# Altium schematic sheet Page1_Microcontrolador.SchDoc
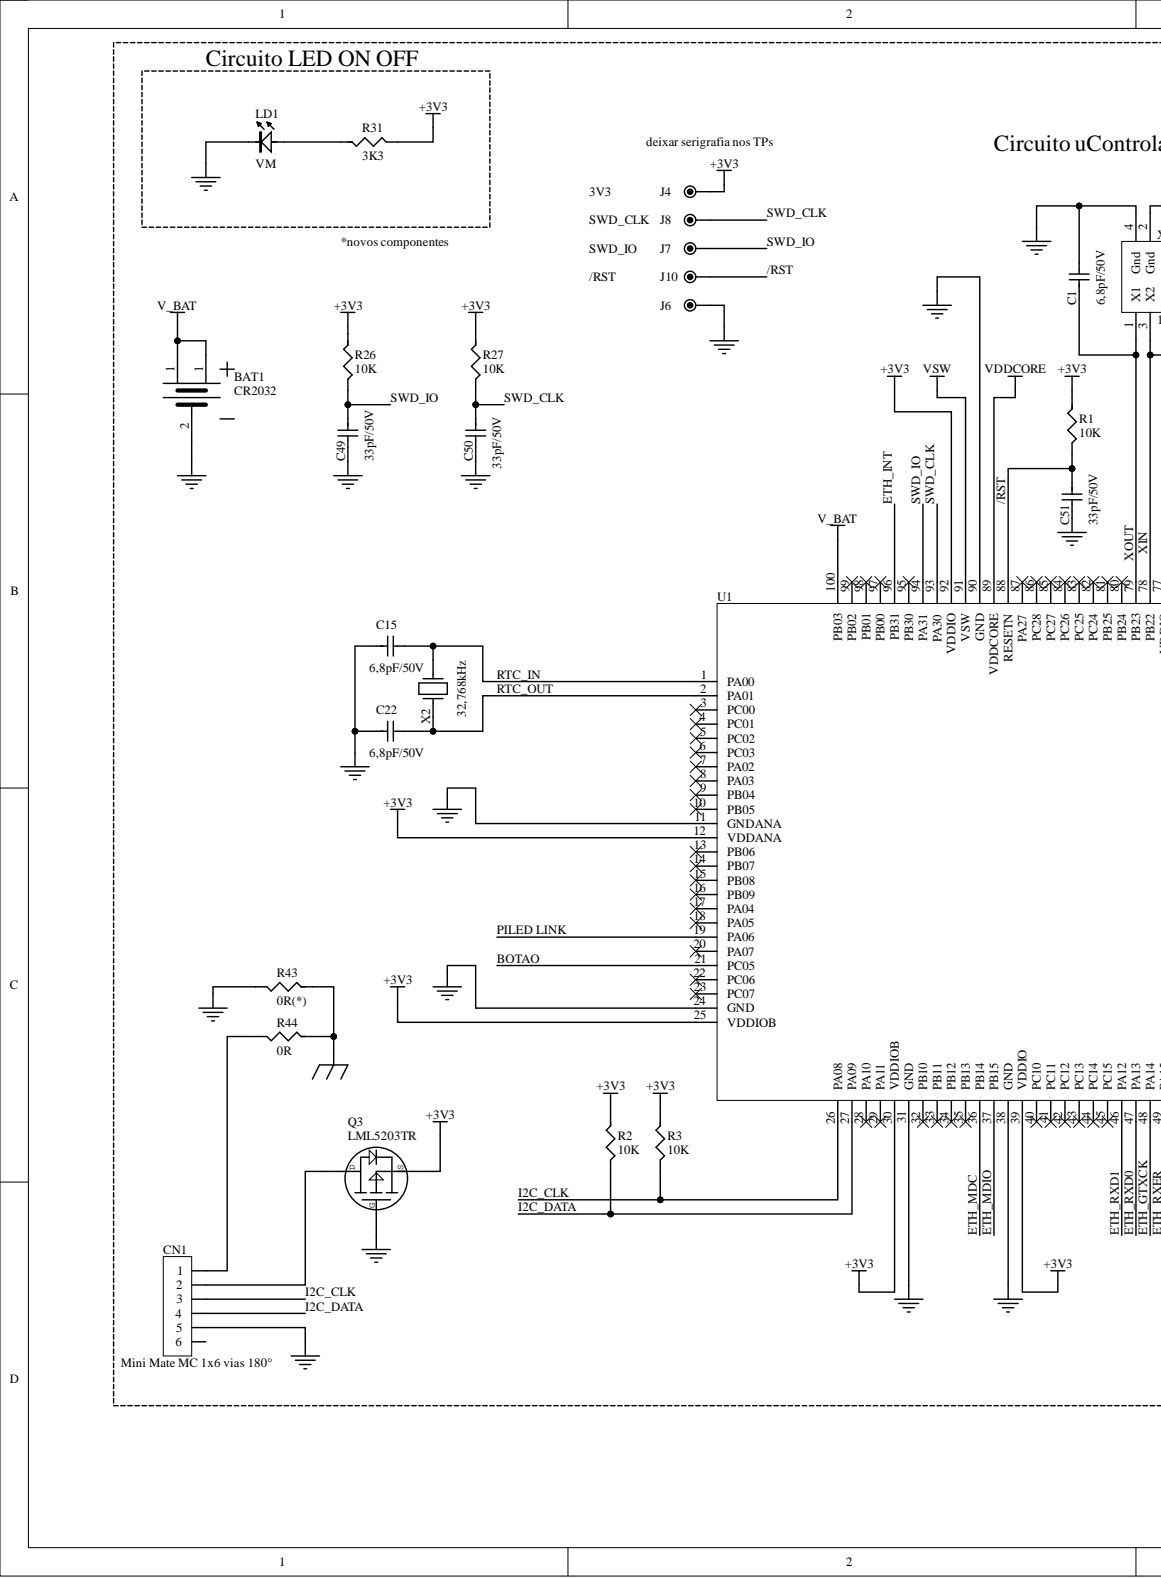
[diagram p0: Page1_Microcontrolador.SchDoc, left]
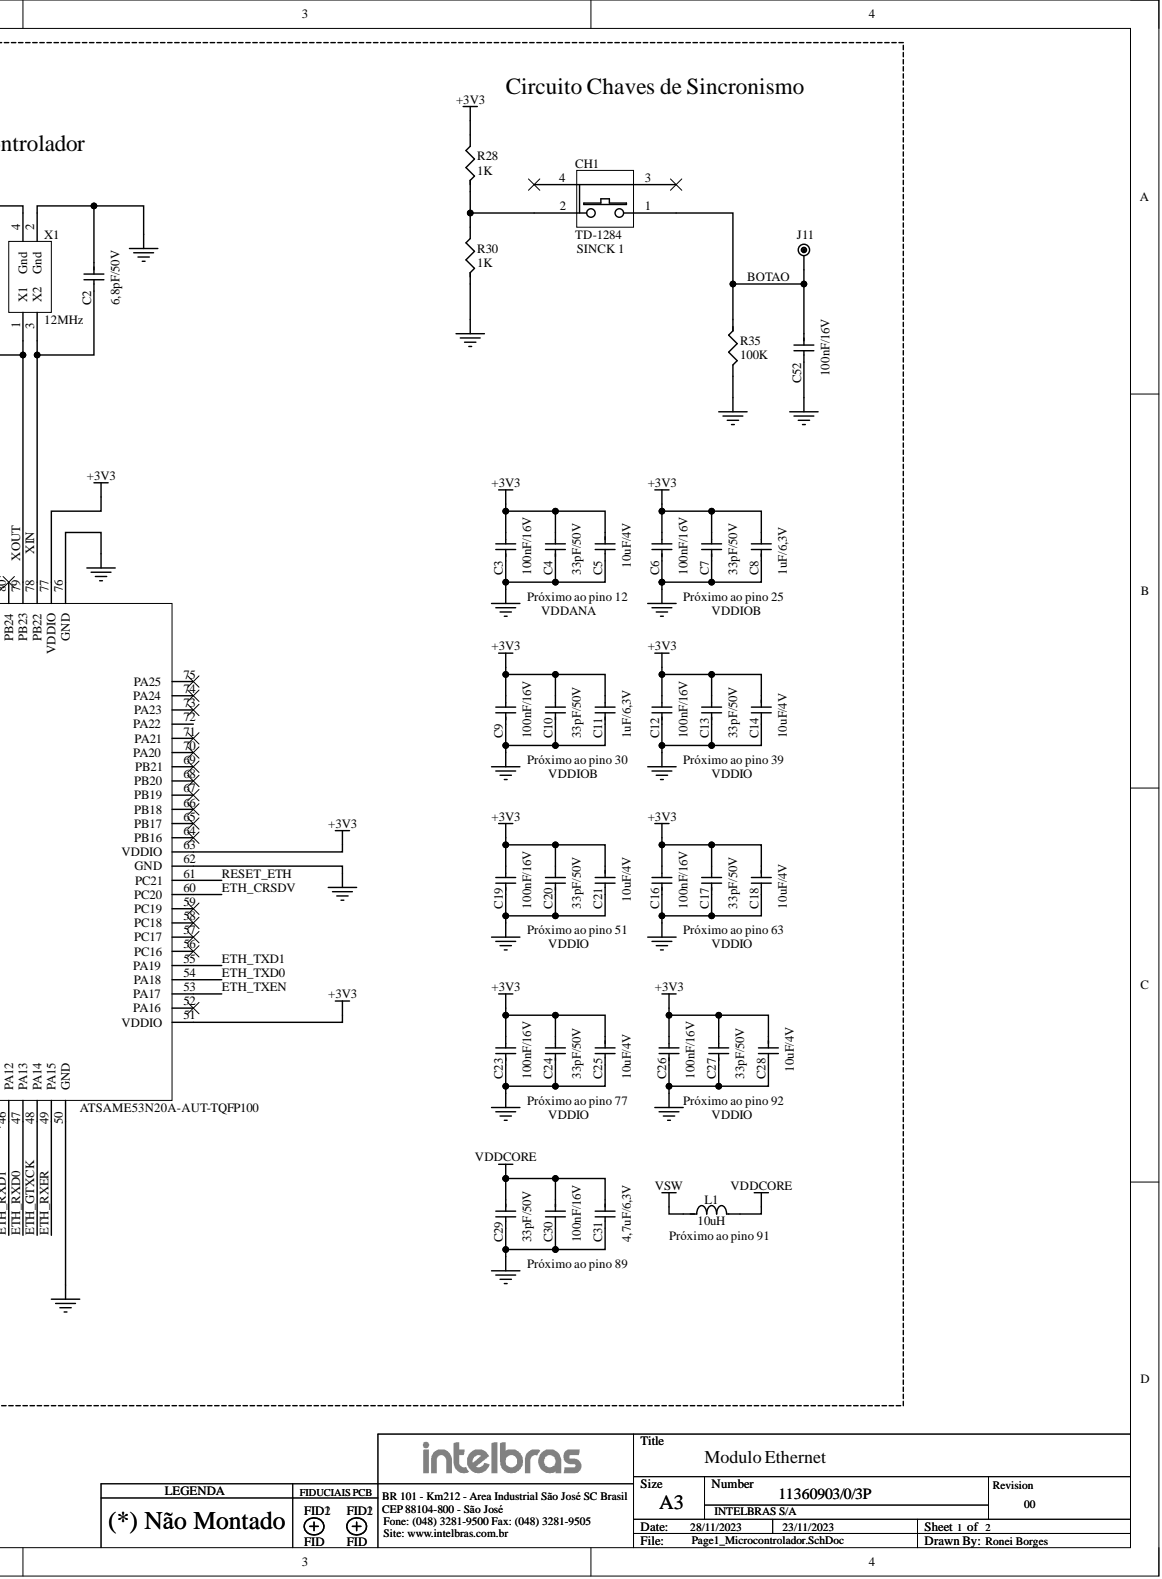
[diagram p1: Page1_Microcontrolador.SchDoc, right]

## Parts
BAT1  CR2032  [BAT_SMD CR2032 - 123]
C1  6,8pF/50V  [0402C]
C2  6,8pF/50V  [0402C]
C3  100nF/16V  [0402C]
C4  33pF/50V  [0402C]
C5  10uF/4V  [0603]
C6  100nF/16V  [0402C]
C7  33pF/50V  [0402C]
C8  1uF/6,3V  [0402C]
C9  100nF/16V  [0402C]
C10  33pF/50V  [0402C]
C11  1uF/6,3V  [0402C]
C12  100nF/16V  [0402C]
C13  33pF/50V  [0402C]
C14  10uF/4V  [0603C]
C15  6,8pF/50V  [0402C]
C16  100nF/16V  [0402C]
C17  33pF/50V  [0402C]
C18  10uF/4V  [0603C]
C19  100nF/16V  [0402C]
C20  33pF/50V  [0402C]
C21  10uF/4V  [0603C]
C22  6,8pF/50V  [0402C]
C23  100nF/16V  [0402C]
C24  33pF/50V  [0402C]
C25  10uF/4V  [0603C]
C26  100nF/16V  [0402C]
C27  33pF/50V  [0402C]
C28  10uF/4V  [0603C]
C29  33pF/50V  [0402C]
C30  100nF/16V  [0402C]
C31  4,7uF/6,3V  [0402C]
C49  33pF/50V  [0402C]
C50  33pF/50V  [0402C]
C51  33pF/50V  [0402C]
C52  100nF/16V  [0402C]
CH1  TD-1284  [CH_SMD_TACTIL90º_C9L8.8A7.5]
CN1  Mini Mate MC 1x6 vias 180°  [CON_PTH_MINIMATE_M_P2.5C17.5L5.75A7-1X6_ML]
J4  testpoint  [TP_80]  (value not drawn)
J6  testpoint  [TP_80]  (value not drawn)
J7  testpoint  [TP_80]  (value not drawn)
J8  testpoint  [TP_80]  (value not drawn)
J10  testpoint  [TP_80]  (value not drawn)
J11  testpoint  [TP_80]  (value not drawn)
L1  10uH  [1210L]
LD1  VM  [LED_SMD_C1.6L0.8A1]
Q3  LML5203TR  [SOT23-GDS]
R1  10K  [0402]
R2  10K  [0402]
R3  10K  [0402]
R26  10K  [0402]
R27  10K  [0402]
R28  1K  [0402]
R30  1K  [0402]
R31  3K3  [0402]
R35  100K  [0402]
R43  0R(*)  [1206 _0R]
R44  0R  [1206 _0R]
U1  ATSAME53N20A-AUT-TQFP100  [LQFP_P0.5C16L16A1.6-100 - AMT8000]
X1  12MHz  [OSC_SMD_C3.2L2.5A0.75 - b]
X2  32,768kHz  [XTAL_SMD_CP3215X09N]

## Nets
+3V3: C3.2 C4.2 C5.1 C6.2 C7.2 C8.2 C9.2 C10.2 C11.2 C12.2 C13.2 C14.1 C16.2 C17.2 C18.1 C19.2 C20.2 C21.1 C23.2 C24.2 C25.1 C26.2 C27.2 C28.1 J4.1 Q3.S R1.1 R2.1 R3.1 R26.1 R27.1 R28.2 R31.2 U1.12(VDDANA) U1.25(VDDIOB) U1.30(VDDIOB) U1.39(VDDIO) U1.51(VDDIO) U1.63(VDDIO) U1.77(VDDIO) U1.92(VDDIO)
GND: BAT1.2 C1.2 C2.1 C3.1 C4.1 C5.2 C6.1 C7.1 C8.1 C9.1 C10.1 C11.1 C12.1 C13.1 C14.2 C15.1 C16.1 C17.1 C18.2 C19.1 C20.1 C21.2 C22.1 C23.1 C24.1 C25.2 C26.1 C27.1 C28.2 C29.2 C30.2 C31.2 C49.2 C50.2 C51.2 C52.2 CN1.5 J6.1 LD1.K Q3.G R30.1 R35.2 R43.2 U1.11(GNDANA) U1.24(GND) U1.31(GND) U1.38(GND) U1.50(GND) U1.62(GND) U1.76(GND) U1.90(GND) X1.2(Gnd) X1.4(Gnd)
GND_EARTH: R43.1 R44.1
V_BAT: BAT1.1 U1.100(PB03)
VDDCORE: C29.1 C30.1 C31.1 L1.2 U1.89(VDDCORE)
VSW: L1.1 U1.91(VSW)
/RST: C51.1 J10.1 R1.2 U1.88(RESETN)
BOTAO: C52.1 CH1.1 J11.1 R35.1 U1.21(PC05)
ETH_CRSDV: U1.60(PC20)
ETH_GTXCK: U1.48(PA14)
ETH_INT: U1.96(PB31)
ETH_MDC: U1.36(PB14)
ETH_MDIO: U1.37(PB15)
ETH_RXD0: U1.47(PA13)
ETH_RXD1: U1.46(PA12)
ETH_RXER: U1.49(PA15)
ETH_TXD0: U1.54(PA18)
ETH_TXD1: U1.55(PA19)
ETH_TXEN: U1.53(PA17)
I2C_CLK: CN1.3 R3.2 U1.26(PA08)
I2C_DATA: CN1.4 R2.2 U1.27(PA09)
PILED LINK: U1.19(PA06)
RESET_ETH: U1.61(PC21)
SWD_CLK: C50.1 J8.1 R27.2 U1.93(PA30)
SWD_IO: C49.1 J7.1 R26.2 U1.94(PA31)
NetC1_1: C1.1 U1.79(PB23) X1.1
NetC2_2: C2.2 U1.78(PB22) X1.3(X2)
NetC15_2: C15.2 U1.1(PA00) X2.2
NetC22_2: C22.2 U1.2(PA01) X2.1
NetCH1_2: CH1.2 R28.1 R30.2
NetCN1_1: CN1.1 R44.2
NetCN1_2: CN1.2 Q3.D
NetLD1_A: LD1.A R31.1

Not connected: CH1 3 4; CN1 6; U1 3(PC00) 4(PC01) 5(PC02) 6(PC03) 7(PA02) 8(PA03) 9(PB04) 10(PB05) 13(PB06) 14(PB07) 15(PB08) 16(PB09) 17(PA04) 18(PA05) 20(PA07) 22(PC06) 23(PC07) 28(PA10) 29(PA11) 32(PB10) 33(PB11) 34(PB12) 35(PB13) 40(PC10) 41(PC11) 42(PC12) 43(PC13) 44(PC14) 45(PC15) 52(PA16) 56(PC16) 57(PC17) 58(PC18) 59(PC19) 64(PB16) 65(PB17) 66(PB18) 67(PB19) 68(PB20) 69(PB21) 70(PA20) 71(PA21) 72(PA22) 73(PA23) 74(PA24) 75(PA25) 80(PB24) 81(PB25) 82(PC24) 83(PC25) 84(PC26) 85(PC27) 86(PC28) 87(PA27) 95(PB30) 97(PB00) 98(PB01) 99(PB02)

## Library data of the parts
BAT1 = 1500613: Contato SMD C5191 Placa XAC 4000; Component Kind: Standard; Library Name: Diversos.SchLib
C1 C2 C15 C22 = 1313715: Capacitor MLC SMD 0402 NP0 50 V ±0,1 pF 6,8 pF; Component Kind: Standard; Library Name: Capacitor.SchLib
C3 C6 C9 C12 C16 C19 C23 C26 C30 C52 = 1313697: Capacitor MLC SMD 0402 X7R 16 V ±10% 100 nF; Component Kind: Standard; Library Name: Capacitor.SchLib
C4 C7 C10 C13 C17 C20 C24 C27 C29 C49-C51 = 1313731: Capacitor MLC SMD 0402 NP0 50 V ±5% 33 pF; Component Kind: Standard; Library Name: Capacitor.SchLib
C5 C14 C18 C21 C25 C28 = 1313874: Capacitor MLC SMD 0603 X5R 4 V ±20% 10 uF; Component Kind: Standard; Library Name: Capacitor.SchLib
C8 C11 = 1313913: CP MC 0402 1uF X5R 6.3V +/-10%; Component Kind: Standard; Library Name: Capacitor.SchLib
C31 = 1310222: Capacitor SMD MLC 0402 X5R 6,3V 4,7uF ±20%; Component Kind: Standard; Library Name: Capacitor.SchLib
CH1 = 1180016: Chave Tact SMD 1x1 12 V 50mA 90° 7,0 mm; FAbricante: KWAN DA; Component Kind: Standard; Library Name: Conectores.SchLib
CN1 = 1131134: Conector PTH Mini Mate MC 1x6 vias 180° 250 V 3 A; Component Kind: Standard; Library Name: Conectores.SchLib
J4 J6-J8 J10 J11 = TestPoint_Jiga_80: Component Kind: Standard; Library Name: Diversos.SchLib
L1 = 1430270: Indutor SMD 1210 10uH ±10%; Component Kind: Standard; Library Name: Indutor.SchLib
LD1 = 1730142: LED SMD 1,6x0,8 mm VM Difuso 60 mW 5 V 25 mA; Component Kind: Standard; Library Name: Diodos.SchLib; MSL: 2
Q3 = 1060676: Transistor SMD SOT-23 MOS-P LML5203TR; Component Kind: Standard; Library Name: Transistor.SchLib
R1-R3 R26 R27 = 1015398: Resistor SMD 0402 1/16 W ±1% 10 kR; P: 1/16W; %: ±1%; Component Kind: Standard; Library Name: Resistor.SchLib
R28 R30 = 1015153: Resistor SMD 0402 1/16 W ±5% 1 kR; P: 1/16W; %: ±5%; Component Kind: Standard; Library Name: Resistor.SchLib
R31 = 1015366: Resistor SMD 0402 1/16 W ±1% 3,3 kR; P: 1/16W; %: ±1%; Component Kind: Standard; Library Name: Resistor.SchLib
R35 = 1010087: Resistor SMD 0402 1/16 W ±1% 100 kR; P: 1/16W; %: ±1%; Component Kind: Standard; Library Name: Resistor.SchLib
R43 R44 = 1015394: Resistor SMD 1206 0 Ohm 1/4W 1%; %: 1%; Component Kind: Standard; Library Name: Resistor.SchLib; P: 1/4W
U1 = 1020295: CI SMD Microcontrolador ARM C-M4F tQFP-100 ATSAME53N20A; Component Kind: Standard; Library Name: CIs.SchLib
X1 = 1380015: Cristal SMD RSD 3225 10pF ±30ppm 50R 12MHz; Component Kind: Standard; Library Name: Osciladores.SchLib
X2 = 1380013: Cristal SMD RSD 3215 12,5pF ±20ppm 70kR 32,768kHz; Component Kind: Standard; Library Name: Osciladores.SchLib
